# Altium schematic sheet Top_level.SchDoc
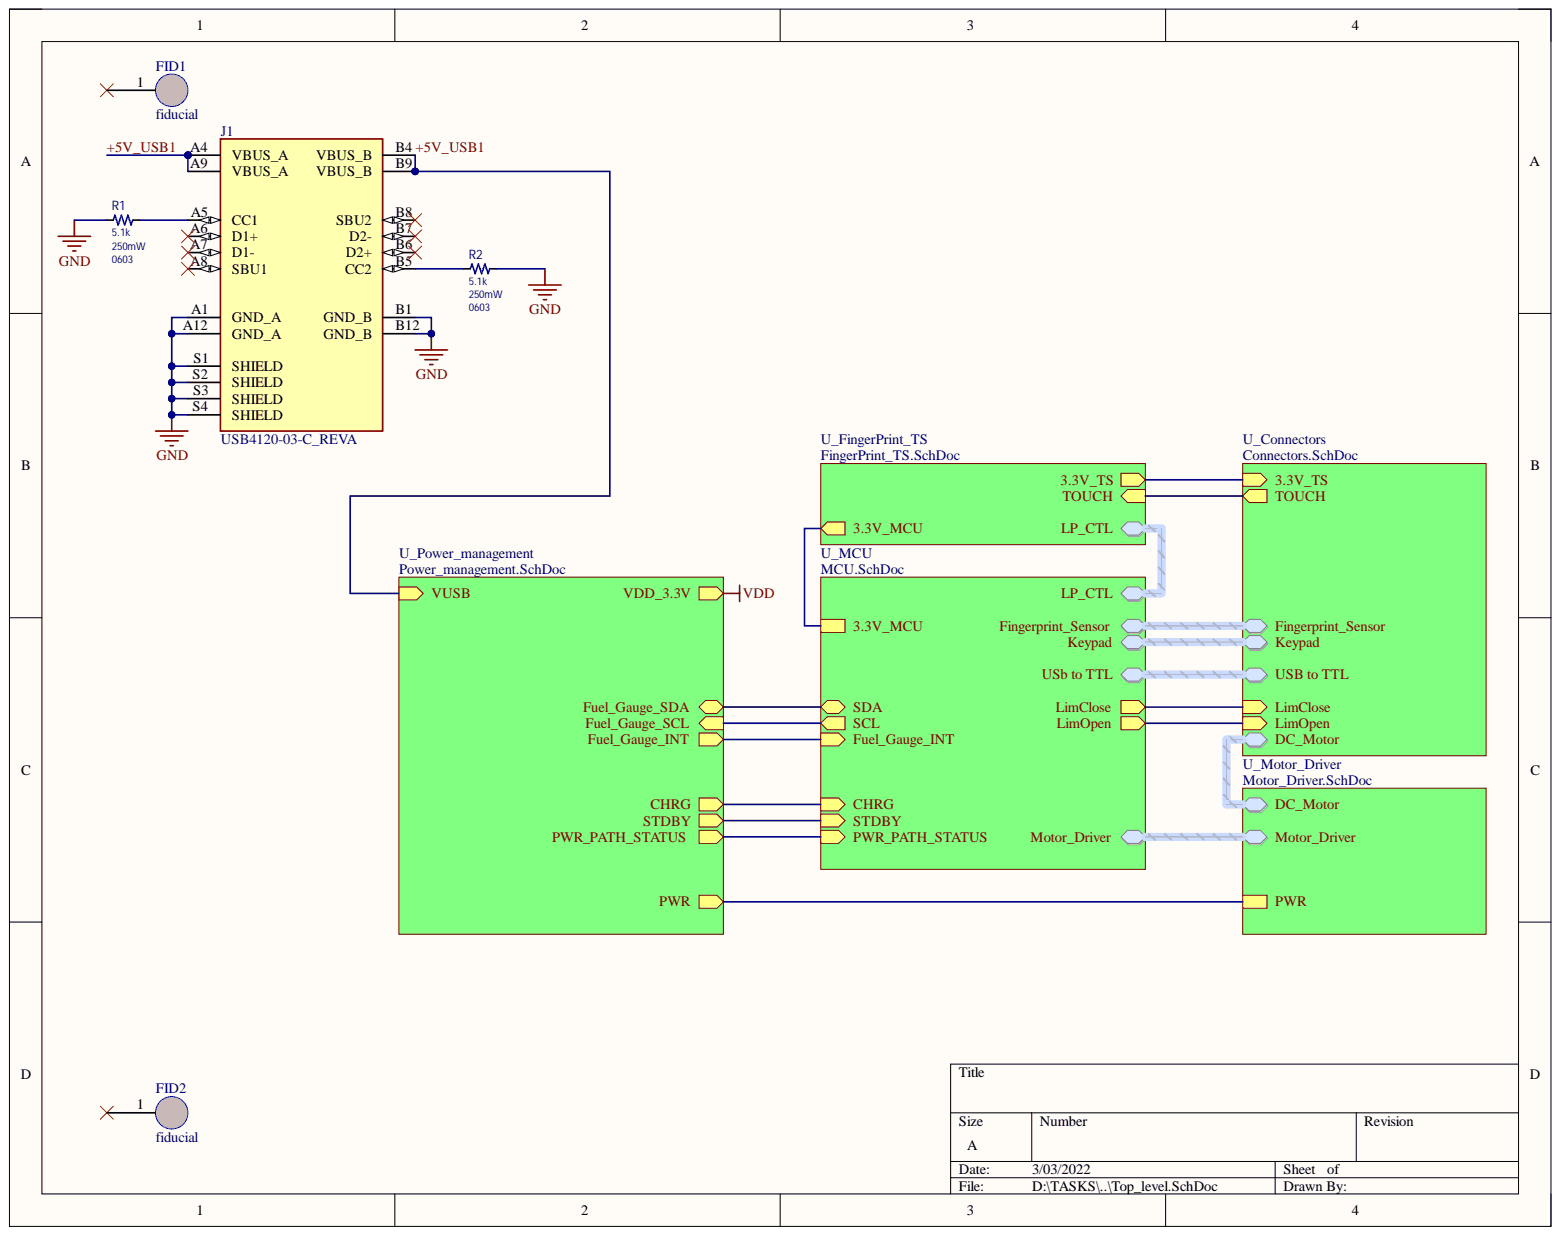
[diagram p0: Top_level.SchDoc]

## Parts
FID1  fiducial  [Fiducial]
FID2  fiducial  [Fiducial]
J1  USB4120-03-C_REVA  [GCT_USB4120-03-C_REVA]
R1  5.1k  Max Power Dissipation: 250mW  [0603-R]
R2  5.1k  Max Power Dissipation: 250mW  [0603-R]

## Nets
GND: J1.A1(GND_A) J1.A12(GND_A) J1.B1(GND_B) J1.B12(GND_B) J1.S1(SHIELD) J1.S2(SHIELD) J1.S3(SHIELD) J1.S4(SHIELD) R1.1 R2.2
+5V_USB1: J1.A4(VBUS_A) J1.A9(VBUS_A) J1.B4(VBUS_B) J1.B9(VBUS_B)
NetFID1_1: FID1.1
NetFID2_1: FID2.1
NetJ1_A5: J1.A5(CC1) R1.2
NetJ1_A6: J1.A6(D1+)
NetJ1_A7: J1.A7(D1-)
NetJ1_A8: J1.A8(SBU1)
NetJ1_B5: J1.B5(CC2) R2.1
NetJ1_B6: J1.B6(D2+)
NetJ1_B7: J1.B7(D2-)
NetJ1_B8: J1.B8(SBU2)
3.3V_MCU:
3.3V_TS:
CHRG:
DC_Motor.OUT1:
DC_Motor.OUT2:
Fingerprint_Sensor.IRQ:
Fingerprint_Sensor.RX1:
Fingerprint_Sensor.TX1:
Fuel_Gauge_INT:
Fuel_Gauge_SCL:
Fuel_Gauge_SDA:
Keypad.Keypad_EN:
Keypad.Keypad_INT:
Keypad.Keypad_LED:
Keypad.Keypad_OUT:
Keypad.Keypad_RST:
Keypad.Keypad_SCL:
Keypad.Keypad_SDA:
LimClose:
LimOpen:
LP_CTL.FPS_PWR_CTL:
LP_CTL.PWR_LATCH:
Motor_Driver.IN1:
Motor_Driver.IN2:
Motor_Driver.nSLEEP:
PWR:
PWR_PATH_STATUS:
STDBY:
TOUCH:
USb to TTL.3.3v_MCU:
USb to TTL.DTR:
USb to TTL.RTS:
USb to TTL.RX0:
USb to TTL.TX0:
VDD:

## Library data of the parts
J1 = USB4120-03-C_REVA: MF: Global Connector Technology; Availability: Unavailable; Check_prices: https://www.snapeda.com/parts/USB4120-03-C/Global%20Connector%20Technology/view-part/5160677/?ref=eda; Component Kind: Standard; MP: USB4120-03-C; Package: None; Price: None; SnapEDA_Link: https://www.snapeda.com/parts/USB4120-03-C/Global%20Connector%20Technology/view-part/5160677/?ref=snap; Library Name: Safety_Lock.IntLib
R1 R2 = Res: Manufacturer: Panasonic; Manufacturer Part Number: ERJ-8GEYJ621V; Resistance: 620R; Resistance Tolerance: 5%; Temperature Coefficient: 200ppm/°C; Voltage Rating: 200V; Case Code (Imperial): 1206; Case Code (Metric): 3216; Case/Package: 0603; Component Kind: Standard; ComponentLink1Description: Datasheet; ComponentLink1URL: https://datasheet.ciiva.com/15193/getdatasheetpartid-366470-15193725.pdf?src-supplier=Verical; ComponentLink2Description: https://datasheet.ciiva.com/46387/1500035-46387094.pdf?src-supplier=Element14; ComponentLink2URL: https://datasheet.ciiva.com/46387/1500035-46387094.pdf?src-supplier=Element14; ComponentLink3Description: https://datasheet.ciiva.com/5915/1500035-5915369.pdf?src-supplier=Farnell; ComponentLink3URL: https://datasheet.ciiva.com/5915/1500035-5915369.pdf?src-supplier=Farnell; ComponentLink4Description: https://datasheet.ciiva.com/28677/1678796-28677018.pdf?src-supplier=Element14; ComponentLink4URL: https://datasheet.ciiva.com/28677/1678796-28677018.pdf?src-supplier=Element14; ComponentLink5Description: https://datasheet.ciiva.com/17204/aoa0000ce1-17204972.pdf?src-supplier=Avnet+Europe; ComponentLink5URL: https://datasheet.ciiva.com/17204/aoa0000ce1-17204972.pdf?src-supplier=Avnet+Europe; ComponentLink6Description: https://datasheet.ciiva.com/16515/aoa0000ce1-16515933.pdf?src-supplier=Avnet+Asia; ComponentLink6URL: https://datasheet.ciiva.com/16515/aoa0000ce1-16515933.pdf?src-supplier=Avnet+Asia; Construction: Rectangular; Contact Plating: Tin; Depth: 1.6mm; Features: Automotive AEC-Q200; Height: 0.028inch; Lead Free: Lead Free; Length: 3.2mm; Max Operating Temperature: 155°C; Military Standard: Not; Min Operating Temperature: -55°C; Mount: Surface Mount; Number of Terminations: 2; Package Quantity: 1; Packaging: Tape and Reel; Power Rating: 250mW; Pricing 1: 1 = 0.01200 (USD); Radiation Hardening: No; REACH SVHC: No SVHC; Resistor Type: Thick Film; RoHS: Yes; RoHS Compliant: Yes; Series: ERJ; Stock 1: 6255; Supplier 1: Avnet; Supplier Part Number 1: 65T8955; Tolerance: 5%; Voltage Rating (DC): 200V; Width: 0.063inch; Library Name: Safety_Lock.IntLib
FID1 FID2 = fiducial: Component Kind: Standard; Library Name: Safety_Lock.IntLib
